# Altium schematic sheet SD.SchDoc(SD)
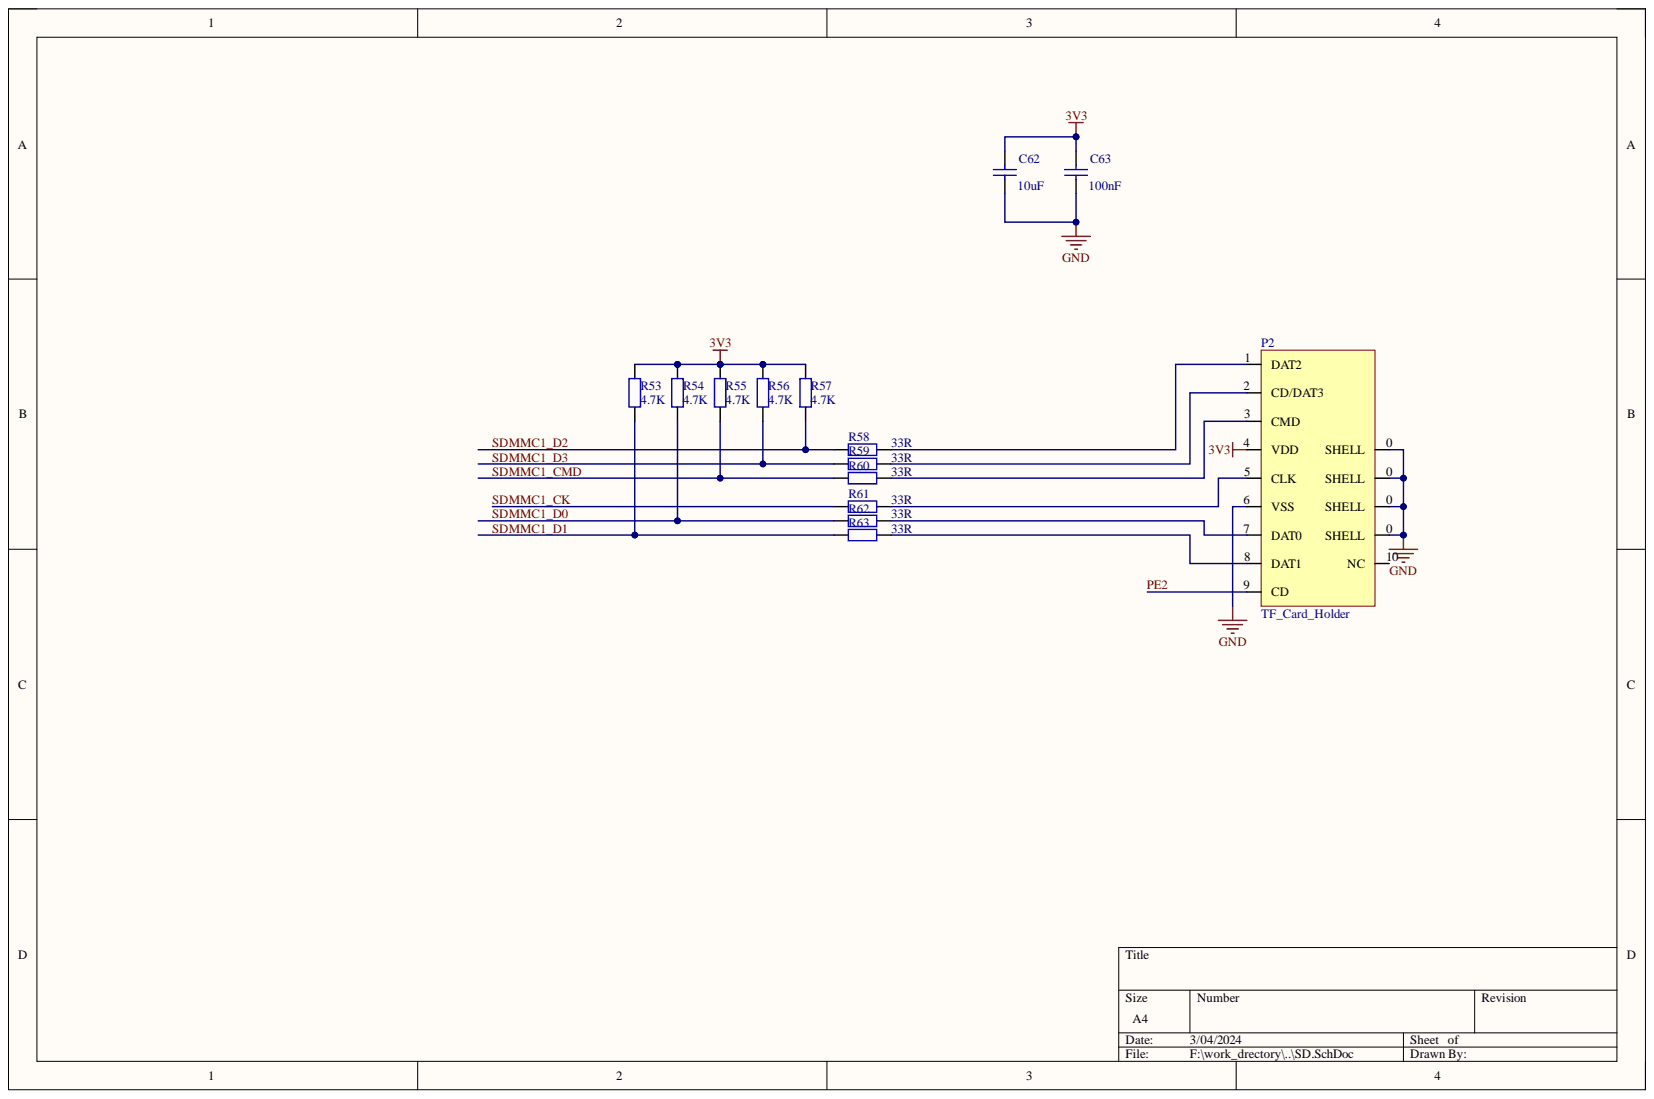
[diagram p0: SD.SchDoc]

## Parts
C62  10uF  [0603_C]
C63  100nF  [0402_C]
P2  TF_Card_Holder  [SD-MICRO-TF]
R53  4.7K  [0402_R]
R54  4.7K  [0402_R]
R55  4.7K  [0402_R]
R56  4.7K  [0402_R]
R57  4.7K  [0402_R]
R58  33R  [0402_R]
R59  33R  [0402_R]
R60  33R  [0402_R]
R61  33R  [0402_R]
R62  33R  [0402_R]
R63  33R  [0402_R]

## Nets
3V3: C62.1 C63.1 P2.4(VDD) R53.2 R54.2 R55.2 R56.2 R57.2
GND: C62.2 C63.2 P2.0(SHELL) P2.6(VSS)
PE2: P2.9(CD)
SDMMC1_CK: R61.2
SDMMC1_CMD: R55.1 R60.2
SDMMC1_D0: R54.1 R62.2
SDMMC1_D1: R53.1 R63.2
SDMMC1_D2: R57.1 R58.2
SDMMC1_D3: R56.1 R59.2
NetP2_1: P2.1(DAT2) R58.1
NetP2_2: P2.2(CD/DAT3) R59.1
NetP2_3: P2.3(CMD) R60.1
NetP2_5: P2.5(CLK) R61.1
NetP2_7: P2.7(DAT0) R62.1
NetP2_8: P2.8(DAT1) R63.1

Not connected: P2 10(NC)

## Library data of the parts
C62 C63 = Cap: 25v; Component Kind: Standard; ComponentLink1Description: 供应商链接; ComponentLink1URL: http://www.szlcsc.com/product/details_1875.html; Library Name: MY_LIB.SchLib; Package: 0402; Supplier: LC; SuppliersPartNumber: C1523
P2 = TF_Card: Component Kind: Standard; Library Name: MY_LIB.SchLib
R53-R63 = Res: 贴片电阻; value: 0Ω; Component Kind: Standard; ComponentLink1Description: 供应商链接; ComponentLink1URL: http://www.szlcsc.com/product/details_17853.html; Library Name: MY_LIB.SchLib; Package: 0402; Supplier: LC; SuppliersPartNumber: C17168
